# Altium schematic sheet pca63513.SchDoc
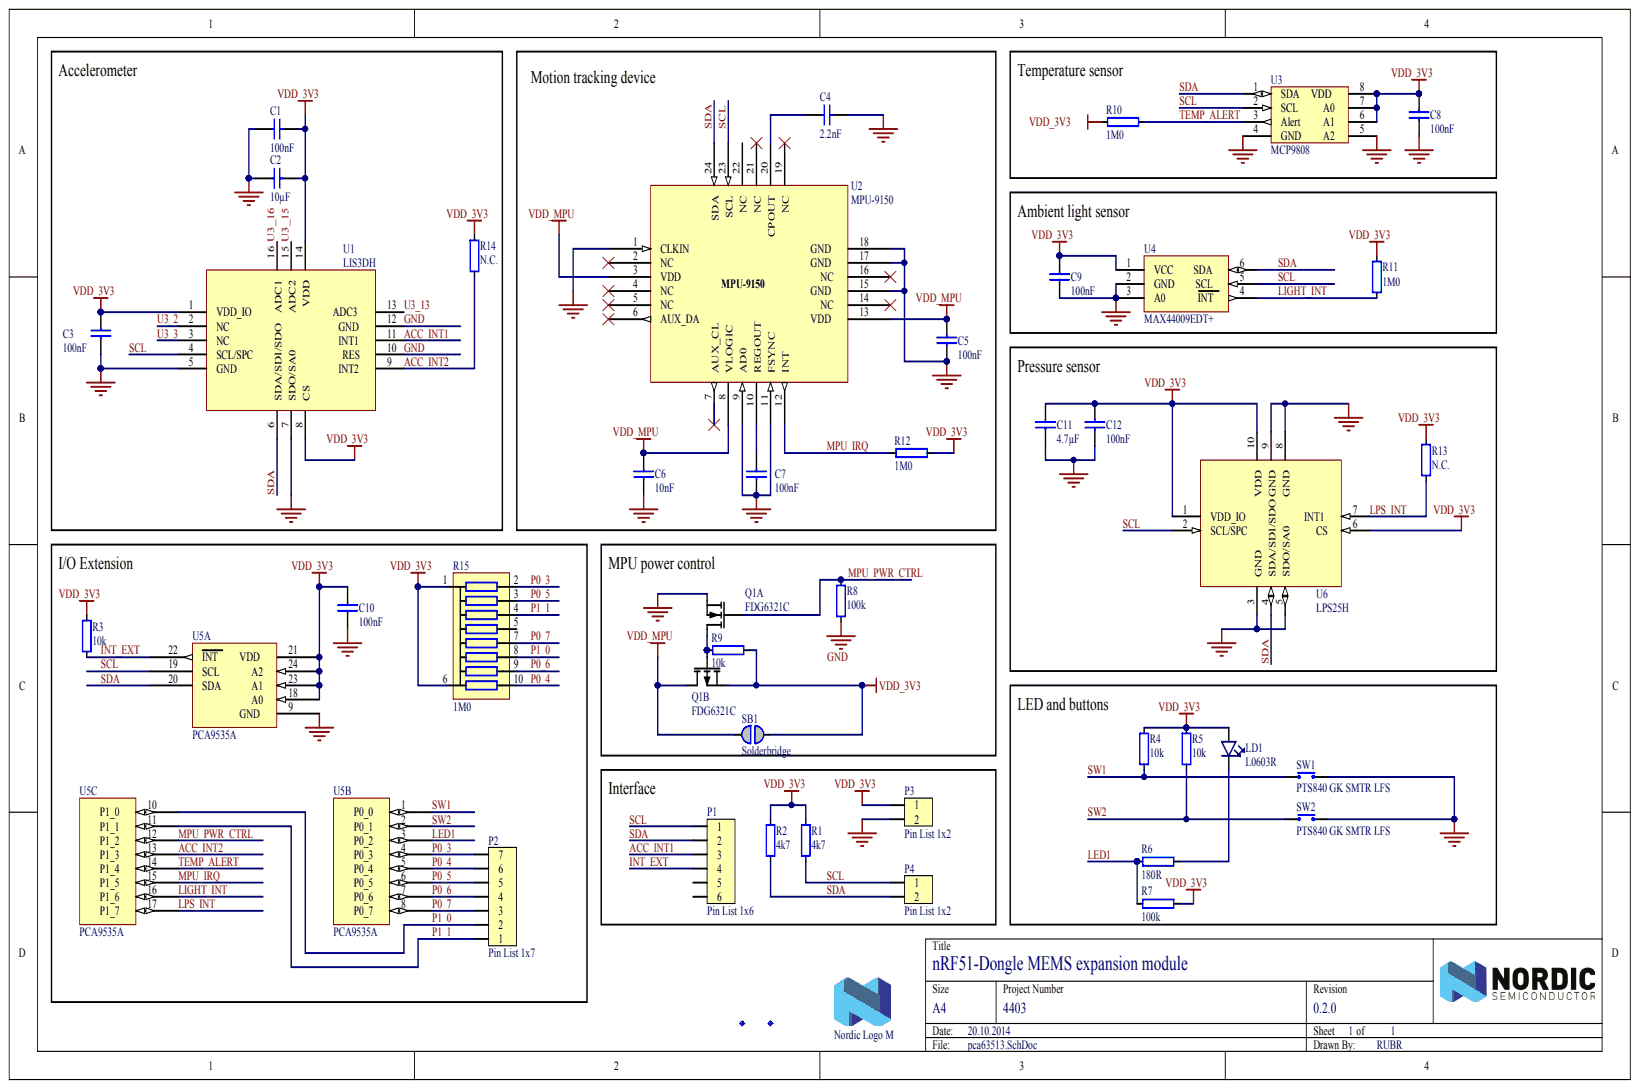
[diagram p0: pca63513.SchDoc]

## Parts
C1  100nF  [CAPC1005X04L]
C2  10µF  [CAPC2012X11L]
C3  100nF  [CAPC1005X04L]
C4  2.2nF  [CAPC1005X04L]
C5  100nF  [CAPC1005X04L]
C6  10nF  [CAPC1005X04L]
C7  100nF  [CAPC1005X04L]
C8  100nF  [CAPC1005X04L]
C9  100nF  [CAPC1005X04L]
C10  100nF  [CAPC1005X04L]
C11  4.7µF  [CAPC1005X04L]
C12  100nF  [CAPC1005X04L]
Fid1  Fiducial mark  [Fiducial]  (value not drawn)
Fid2  Fiducial mark  [Fiducial]  (value not drawn)
LD1  L0603R  [LED_0603_R]
LOGO1  Nordic Logo M  [NORDIC_LOGO_M]  (no BOM)
P1  Pin List 1x6  [LIST_1x6]
P2  Pin List 1x7  [LIST_1x7]
P3  Pin List 1x2  [LIST_1x2]
P4  Pin List 1x2  [LIST_1x2]
Q1  FDG6321C  [SOT65P210X100-6N]  (parts A,B)
R1  4k7  [RESC1005X04L]
R2  4k7  [RESC1005X04L]
R3  10k  [RESC1005X04L]
R4  10k  [RESC1005X04L]
R5  10k  [RESC1005X04L]
R6  180R  [RESC1005X04L]
R7  100k  [RESC1005X04L]
R8  100k  [RESC1005X04L]
R9  10k  [RESC1005X04L]
R10  1M0  [RESC1005X04L]
R11  1M0  [RESC1005X04L]
R12  1M0  [RESC1005X04L]
R13  N.C.  [RESC1005X04L]
R14  N.C.  [RESC1005X04L]
R15  1M0  [RESARRAY-EXBE]
SB1  Solderbridge  [Solderbridge]  (no BOM)
SW1  PTS840 GK SMTR LFS  [EVQP7A/J/B/K]
SW2  PTS840 GK SMTR LFS  [EVQP7A/J/B/K]
U1  LIS3DH  [LGA-16 (3x3x1.0mm)]
U2  MPU-9150  [QFN50P400X400X110-24N]
U3  MCP9808  [MSOP65P490X110-8N]
U4  MAX44009EDT+  [QFN65P200X200X65-6N]
U5  PCA9535A  [QFN50P400X400X80_HS-25N]  (parts A,B,C)
U6  LPS25H  [HCLGA-10L (2.5x2.5x1.0mm)]

## Nets
VDD_3V3: C1.2 C2.2 C3.2 C8.2 C9.2 C10.2 C11.2 C12.2 LD1.2 P3.1 Q1.4 R1.2 R2.2 R3.2 R4.2 R5.2 R7.2 R9.1 R10.2 R11.2 R12.1 R13.2 R14.2 R15.1 R15.6 SB1.2 U1.1(VDD_IO) U1.8(CS) U1.14(VDD) U3.6(A1) U3.7(A0) U3.8(VDD) U4.1(VCC) U5.18(A0) U5.21(VDD) U5.23(A1) U5.24(A2) U6.1(VDD_IO) U6.6(CS) U6.10(VDD)
VDD_MPU: C5.2 C6.2 Q1.3 SB1.1 U2.3(VDD) U2.8(VLOGIC) U2.13(VDD)
ACC_INT1: P1.3 U1.11(INT1)
ACC_INT2: R14.1 U1.9(INT2) U5.13(P1_3)
GND: C1.1 C2.1 C3.1 C4.1 C5.1 C6.1 C7.1 C8.1 C9.1 C10.1 C11.1 C12.1 P3.2 Q1.1 R8.2 SW1.1 SW2.1 U1.5(GND) U1.7(SDO/SA0) U1.10(RES) U1.12(GND) U2.1(CLKIN) U2.9(AD0) U2.11(FSYNC) U2.15(GND) U2.17(GND) U2.18(GND) U3.4(GND) U3.5(A2) U4.2(GND) U4.3(A0) U4.7 U5.9(GND) U5.25 U6.3(GND) U6.5(SDO/SA0) U6.8(GND) U6.9(GND)
INT_EXT: P1.4 R3.1 U5.22(INT)
LED1: R6.2 R7.1 U5.3(P0_2)
LIGHT_INT: R11.1 U4.4(INT) U5.16(P1_6)
LPS_INT: R13.1 U5.17(P1_7) U6.7(INT1)
MPU_IRQ: R12.2 U2.12(INT) U5.15(P1_5)
MPU_PWR_CTRL: Q1.2 R8.1 U5.12(P1_2)
P0_3: P2.7 R15.2 U5.4(P0_3)
P0_4: P2.6 R15.10 U5.5(P0_4)
P0_5: P2.5 R15.3 U5.6(P0_5)
P0_6: P2.4 R15.9 U5.7(P0_6)
P0_7: P2.3 R15.7 U5.8(P0_7)
P1_0: P2.2 R15.8 U5.10(P1_0)
P1_1: P2.1 R15.4 U5.11(P1_1)
SCL: P1.1 P4.1 R1.1 U1.4(SCL/SPC) U2.23(SCL) U3.2(SCL) U4.5(SCL) U5.19(SCL) U6.2(SCL/SPC)
SDA: P1.2 P4.2 R2.1 U1.6(SDA/SDI/SDO) U2.24(SDA) U3.1(SDA) U4.6(SDA) U5.20(SDA) U6.4(SDA/SDI/SDO)
SW1: R4.1 SW1.2 U5.1(P0_0)
SW2: R5.1 SW2.2 U5.2(P0_1)
TEMP_ALERT: R10.1 U3.3(Alert) U5.14(P1_4)
U3_2: U1.2(NC)
U3_3: U1.3(NC)
U3_13: U1.13(ADC3)
U3_15: U1.15(ADC2)
U3_16: U1.16(ADC1)
NetC4_2: C4.2 U2.20(CPOUT)
NetC7_2: C7.2 U2.10(REGOUT)
NetLD1_1: LD1.1 R6.1
NetQ1_5: Q1.5 Q1.6 R9.2

Not connected: P1 5 6; R15 5; U2 2(NC) 4(NC) 5(NC) 6(AUX_DA) 7(AUX_CL) 14(NC) 16(NC) 19(NC) 21(NC) 22(NC)

## Library data of the parts
C1 C3 C5 C7-C10 C12 = C0402-100n: Capacitor, X7R, ±10%; Artikkelnr Noca: 20039200; Artikkelnr Simpro: N.A.; Database Table Name: Capacitors$; Note: 16V; Component Kind: Standard; Footprint Doc: 0402; LatestRevisionDate: 2009-11-23; Library Name: Nordic Passive DB.DbLib; Part Number: C0402-100n; Pin Count: 2; Published: 2009-05-08; Value: 100nF; Publisher: Nordic Semiconductor
C2 = C0805-10µ: Capacitor, X5R, ±10%; Artikkelnr Noca: 20039243; Artikkelnr Simpro: N.A.; Database Table Name: Capacitors$; Note: 16V; Component Kind: Standard; Footprint Doc: 0805; LatestRevisionDate: 2013-06-04; Library Name: Nordic Passive DB.DbLib; Part Number: C0805-10µ; Pin Count: 2; Published: 2010-06-03; Value: 10µF; Publisher: Nordic Semiconductor
C4 = C0402-2n2: Capacitor, X7R, ±10%; Artikkelnr Noca: 20039221; Artikkelnr Simpro: N.A.; Database Table Name: Capacitors$; Note: 50V; Component Kind: Standard; Footprint Doc: 0402; LatestRevisionDate: 2009-11-23; Library Name: Nordic Passive DB.DbLib; Part Number: C0402-2n2; Pin Count: 2; Published: 2009-05-08; Value: 2.2nF; Publisher: Nordic Semiconductor
C6 = C0402-10n: Capacitor, X7R, ±10%; Artikkelnr Noca: 20039166; Artikkelnr Simpro: N.A.; Database Table Name: Capacitors$; Note: 16V; Component Kind: Standard; Footprint Doc: 0402; LatestRevisionDate: 2009-11-23; Library Name: Nordic Passive DB.DbLib; Part Number: C0402-10n; Pin Count: 2; Published: 2009-05-08; Value: 10nF; Publisher: Nordic Semiconductor
C11 = C0402-4µ7: Chip Cap 0402; Artikkelnr Noca: N.A.; Database Table Name: Capacitors$; Note: Chip Cap 1%; Artikkelnr Simpro: N.A.; Component Kind: Standard; Footprint Doc: 0402; LatestRevisionDate: 2009-05-08; Library Name: Nordic Passive DB.DbLib; Part Number: C0402-4µ7; Pin Count: 2; Published: 2009-05-08; Value: 4.7µF; Publisher: Nordic Semiconductor
Fid1 Fid2 = Fid-Mark: Artikkelnr Noca: N.A.; Database Table Name: Connectors$; Artikkelnr Simpro: N.A.; Component Kind: Standard; Description: Fiducial Mark; Footprint Doc: Fiducial; LatestRevisionDate: 2009-12-12; Library Name: Nordic Passive DB.DbLib; Part Number: Fid-Mark; Pin Count: 1; Published: 2009-12-12; Publisher: Nordic Semiconductor
LD1 = EL19-21SURC: LED 0603, EL19-21SURC; Manufacturer: Everlight; Manufacturer Part Number: EL-19-21SURC/S530-A2/TR8; Artikkelnr Noca: 13019043; Artikkelnr Simpro: N.A.; Database Table Name: Optoelectronics$; Note: 39 mcd @ 20 mA; Component Kind: Standard; Datasheet: http://media.digikey.com/pdf/Data%20Sheets/Everlight%20PDFs/19-21SURC,S530-A3,TR8.pdf; Footprint Doc: 0603; LatestRevisionDate: 2013-06-04; Library Name: Nordic Discrete DB.DbLib; Part Number: EL19-21SURC; Pin Count: 2; Published: 2009-05-18; Supplier: Mouser; Supplier part number: 638-192SURCS530-A2; Value: L0603R; Publisher: Nordic Semiconductor
LOGO1 = Nordic Logo M: Nordic Logo, medium size; Artikkelnr Noca: N.A.; Database Table Name: Connectors$; Artikkelnr Simpro: N.A.; Footprint Doc: NORDIC_LOGO_M; LatestRevisionDate: 2010-02-18; Library Name: Nordic Passive DB.DbLib; Part Number: Nordic Logo M; Pin Count: 2; Published: 2010-02-18; Value: Nordic Logo M; Publisher: Nordic Semiconductor
P1 = Pin List 1x6: Pin List 1x6, 2.54mm (100mil); Artikkelnr Noca: 56019043; Artikkelnr Simpro: N.A.; Database Table Name: Connectors$; Component Kind: Standard; Footprint Doc: LIST_1x6; LatestRevisionDate: 2009-12-12; Library Name: Nordic Passive DB.DbLib; Part Number: Pin List 1x6; Pin Count: 6; Published: 2009-12-12; Value: Pin List 1x6; Publisher: Nordic Semiconductor
P2 = Pin List 1x7: Pin List 1x7, 2.54mm (100mil); Artikkelnr Noca: N.A.; Database Table Name: Connectors$; Artikkelnr Simpro: N.A.; Component Kind: Standard; Footprint Doc: LIST_1x7; LatestRevisionDate: 2009-12-12; Library Name: Nordic Passive DB.DbLib; Part Number: Pin List 1x7; Pin Count: 7; Published: 2009-12-12; Value: Pin List 1x7; Publisher: Nordic Semiconductor
P3 P4 = Pin List 1x2: Pin List 1x2, 2.54mm (100mil); Artikkelnr Noca: 56019040; Artikkelnr Simpro: N.A.; Database Table Name: Connectors$; Component Kind: Standard; Footprint Doc: LIST_1x2; LatestRevisionDate: 2009-12-12; Library Name: Nordic Passive DB.DbLib; Part Number: Pin List 1x2; Pin Count: 2; Published: 2009-12-12; Value: Pin List 1x2; Publisher: Nordic Semiconductor
Q1 = FDG6321C_IPC: Fairchild, FDG6321C, Dual N & P Channel Digital FET; 2nd source part number: FDG6321CCT-ND; Artikkelnr Noca: 35029089; Artikkelnr Simpro: N.A.; Database Table Name: Semiconductors$; 2nd source Supplier: Digi-Key; Component Kind: Standard; Datasheet: http://www.fairchildsemi.com/ds/FD/FDG6321C.pdf; Footprint Doc: SC70-6; LatestRevisionDate: 2011-07-04; Library Name: Nordic Discrete DB.DbLib; Manufacturer: Fairchild; Manufacturer Part Number: FDG6321C; Note: Dual N & P Channel Digital FET; Part Number: FDG6321C_IPC; Pin Count: 6; Published: 2012-07-04; Supplier: Farnell; Supplier part number: 170-0689; Value: FDG6321C; Publisher: Nordic Semiconductor
R1 R2 = R0402-4k7: Resistor, ±1%, 0.063W; Artikkelnr Noca: 27049089; Artikkelnr Simpro: N.A.; Database Table Name: Resistors$; Note: Chip Res 1%; Component Kind: Standard; Footprint Doc: 0402; LatestRevisionDate: 2009-11-23; Library Name: Nordic Passive DB.DbLib; Part Number: R0402-4k7; Pin Count: 2; Published: 2009-05-08; Supplier: Farnell; Supplier part number: 173-8858; Value: 4k7; Publisher: Nordic Semiconductor
R3-R5 R9 = R0402-10k: Resistor, ±1%, 0.063W; Artikkelnr Noca: 27049097; Artikkelnr Simpro: N.A.; Database Table Name: Resistors$; Note: Chip Res 1%; Component Kind: Standard; Footprint Doc: 0402; LatestRevisionDate: 2009-11-23; Library Name: Nordic Passive DB.DbLib; Part Number: R0402-10k; Pin Count: 2; Published: 2009-05-08; Supplier: Farnell; Supplier part number: 173-8864; Value: 10k; Publisher: Nordic Semiconductor
R6 = R0402-180: Resistor, ±1%, 0.063W; Artikkelnr Noca: 27049055; Artikkelnr Simpro: N.A.; Database Table Name: Resistors$; Note: Chip Res 1%; Component Kind: Standard; Footprint Doc: 0402; LatestRevisionDate: 2009-11-23; Library Name: Nordic Passive DB.DbLib; Part Number: R0402-180; Pin Count: 2; Published: 2009-05-08; Supplier: Farnell; Supplier part number: 173-8840; Value: 180R; Publisher: Nordic Semiconductor
R7 R8 = R0402-100k: Resistor, ±1%, 0.063W; Artikkelnr Noca: 27049121; Artikkelnr Simpro: N.A.; Database Table Name: Resistors$; Note: Chip Res 1%; Component Kind: Standard; Footprint Doc: 0402; LatestRevisionDate: 2009-11-23; Library Name: Nordic Passive DB.DbLib; Part Number: R0402-100k; Pin Count: 2; Published: 2009-05-08; Supplier: Farnell; Supplier part number: 173-8877; Value: 100k; Publisher: Nordic Semiconductor
R10-R12 = R0402-1M0: Resistor, ±1%, 0.063W; Artikkelnr Noca: 27049145; Artikkelnr Simpro: N.A.; Database Table Name: Resistors$; Note: Chip Res 1%; Component Kind: Standard; Footprint Doc: 0402; LatestRevisionDate: 2009-11-23; Library Name: Nordic Passive DB.DbLib; Part Number: R0402-1M0; Pin Count: 2; Published: 2009-05-08; Value: 1M0; Publisher: Nordic Semiconductor
R13 R14 = R0402-N.C.: Not mounted; Artikkelnr Noca: N.A.; Database Table Name: Resistors$; Note: Chip Res; Artikkelnr Simpro: N.A.; Component Kind: Standard; Footprint Doc: 0402; LatestRevisionDate: 2009-05-08; Library Name: Nordic Passive DB.DbLib; Part Number: R0402-N.C.; Pin Count: 2; Published: 2009-05-08; Value: N.C.; Publisher: Nordic Semiconductor
R15 = EXBE-1M0: Resistor Array, ±5%, 0.063W; Manufacturer: Panasonic; Manufacturer Part Number: EXB-E10C105J; Artikkelnr Noca: N.A.; Database Table Name: Resistors$; Artikkelnr Simpro: N.A.; Component Kind: Standard; Datasheet: http://industrial.panasonic.com/www-data/pdf/AOC0000/AOC0000CE3.pdf; Footprint Doc: 1608; LatestRevisionDate: 2014-09-10; Library Name: Nordic Passive DB.DbLib; Part Number: EXBE-1M0; Pin Count: 10; Published: 2014-09-10; Supplier: Digi-Key; Supplier part number: U8105CT-ND; Value: 1M0; Publisher: Nordic Semiconductor
SB1 = Solderbridge: Artikkelnr Noca: N.A.; Database Table Name: Connectors$; Artikkelnr Simpro: N.A.; Description: Solderbridge; Footprint Doc: Solderbridge; LatestRevisionDate: 2009-12-14; Library Name: Nordic Passive DB.DbLib; Part Number: Solderbridge; Pin Count: 2; Published: 2009-12-14; Value: Solderbridge; Publisher: Nordic Semiconductor
SW1 SW2 = PTS840 GK SMTR LFS: Microminiature SMT Switch, Side Actuated; 2nd source part number: 611-PTS840GKSMTR; Manufacturer: C & K; Artikkelnr Noca: N.A.; Database Table Name: Rele_Switch$; Note: Tactile Switch, SPST, SMD, 220gf; 2nd source Supplier: Mouser; Artikkelnr Simpro: N.A.; Component Kind: Standard; Datasheet: http://www.ck-components.com/80185/pts840_11june13.pdf/; Footprint Doc: PTS840 Gx; LatestRevisionDate: 2014-08-12; Library Name: Nordic Discrete DB.DbLib; Manufacturer Part Number: PTS840 GK SMTR LFS; Part Number: PTS840 GK SMTR LFS; Pin Count: 4; Published: 2014-08-12; Supplier: Digi-Key; Supplier part number: CKN10508CT-ND; Value: PTS840 GK SMTR LFS; Publisher: Nordic Semiconductor
U1 = LIS3DH: 3-axis - ±2g/±4g/±8g/±16g digital output nano accelerometer; 2nd source part number: 187-2923; Manufacturer: STMicroelectronics; Artikkelnr Noca: 15079978; Artikkelnr Simpro: N.A.; Database Table Name: Misc IC$; Note: MEMS digital output motion sensor ultra low-power high performance 3-axes "nano" accelerometer; 2nd source Supplier: Farnell; Component Kind: Standard; Datasheet: http://www.st.com/internet/com/TECHNICAL_RESOURCES/TECHNICAL_LITERATURE/DATASHEET/CD00274221.pdf; Footprint Doc: LGA-16; LatestRevisionDate: 2011-08-30; Library Name: Nordic Active DB.DbLib; Manufacturer Part Number: LIS3DH; Part Number: LIS3DH; Pin Count: 16; Published: 2011-08-30; Supplier: Digi-Key; Supplier part number: 497-10613-1-ND; Value: LIS3DH; Publisher: Nordic Semiconductor
U2 = MPU-9150: 9-axis MotionTracking™ Device; Manufacturer: InvenSense; Artikkelnr Noca: N.A.; Database Table Name: Misc IC$; Note: 3-Axis Gyro, 3-Axis Accel and 3-Axis Compass; Artikkelnr Simpro: N.A.; Component Kind: Standard; Datasheet: http://www.invensense.com/mems/gyro/documents/PS-MPU-9150A-00v4_3.pdf; Footprint Doc: QFN-24; LatestRevisionDate: 2014-08-14; Library Name: Nordic Active DB.DbLib; Manufacturer Part Number: MPU-9150; Part Number: MPU-9150; Pin Count: 24; Published: 2014-08-14; Supplier: Digi-Key; Supplier part number: 1428-1009-1-ND; Value: MPU-9150; Publisher: Nordic Semiconductor
U3 = MCP9808-E/MS: ±0.5°C Maximum Accuracy Digital Temperature Sensor; Manufacturer: Microchip Technology; Artikkelnr Noca: N.A.; Database Table Name: Misc IC$; Artikkelnr Simpro: N.A.; Component Kind: Standard; Datasheet: http://ww1.microchip.com/downloads/en/DeviceDoc/25095A.pdf; Footprint Doc: MSOP-8; LatestRevisionDate: 2013-10-29; Library Name: Nordic Active DB.DbLib; Manufacturer Part Number: MCP9808-E/MS; Part Number: MCP9808-E/MS; Pin Count: 8; Published: 2013-01-24; Supplier: Digi-Key; Supplier part number: MCP9808-E/MS-ND; Value: MCP9808; Publisher: Nordic Semiconductor
U4 = MAX44009EDT+: Low-Power Digital Ambient Light Sensor with Enhanced Sensitivity; Manufacturer: Maxim; Artikkelnr Noca: N.A.; Database Table Name: Misc IC$; Artikkelnr Simpro: N.A.; Component Kind: Standard; Datasheet: http://datasheets.maximintegrated.com/en/ds/MAX44009.pdf; Footprint Doc: UDFN-6; LatestRevisionDate: 2013-01-24; Library Name: Nordic Active DB.DbLib; Manufacturer Part Number: MAX44009EDT+; Part Number: MAX44009EDT+; Pin Count: 6; Published: 2013-01-24; Supplier: Digi-Key; Supplier part number: MAX44009EDT+TCT-ND; Value: MAX44009EDT+; Publisher: Nordic Semiconductor
U5 = PCA9535AHF: Low-voltage 16-bit I2C-bus I/O port with interrupt; 2nd source part number: 771-PCA9535AHF,128; Manufacturer: NXP Semiconductors; Artikkelnr Noca: N.A.; Database Table Name: Misc IC$; 2nd source Supplier: Mouser; Artikkelnr Simpro: N.A.; Component Kind: Standard; Datasheet: http://www.nxp.com/documents/data_sheet/PCA9535A.pdf; Footprint Doc: QFN-24; LatestRevisionDate: 2014-08-21; Library Name: Nordic Active DB.DbLib; Manufacturer Part Number: PCA9535AHF; Part Number: PCA9535AHF; Pin Count: 24; Published: 2014-08-21; Supplier: Digi-Key; Supplier part number: 568-9937-1-ND; Value: PCA9535A; Publisher: Nordic Semiconductor
U6 = LPS25H: MEMS pressure sensor: 260-1260 hPa absolute digital output barometer; 2nd source part number: 511-LPS25HTR; Manufacturer: STMicroelectronics; Artikkelnr Noca: N.A.; Database Table Name: Misc IC$; 2nd source Supplier: Mouser; Artikkelnr Simpro: N.A.; Component Kind: Standard; Datasheet: http://www.st.com/st-web-ui/static/active/en/resource/technical/document/datasheet/DM00066332.pdf; Footprint Doc: HCLGA-10L; LatestRevisionDate: 2014-08-21; Library Name: Nordic Active DB.DbLib; Manufacturer Part Number: LPS25H; Part Number: LPS25H; Pin Count: 10; Published: 2014-08-21; Supplier: Digi-Key; Supplier part number: 497-14380-1-ND; Value: LPS25H; Publisher: Nordic Semiconductor
